# Altium schematic sheet artobot.SchDoc(artobot)
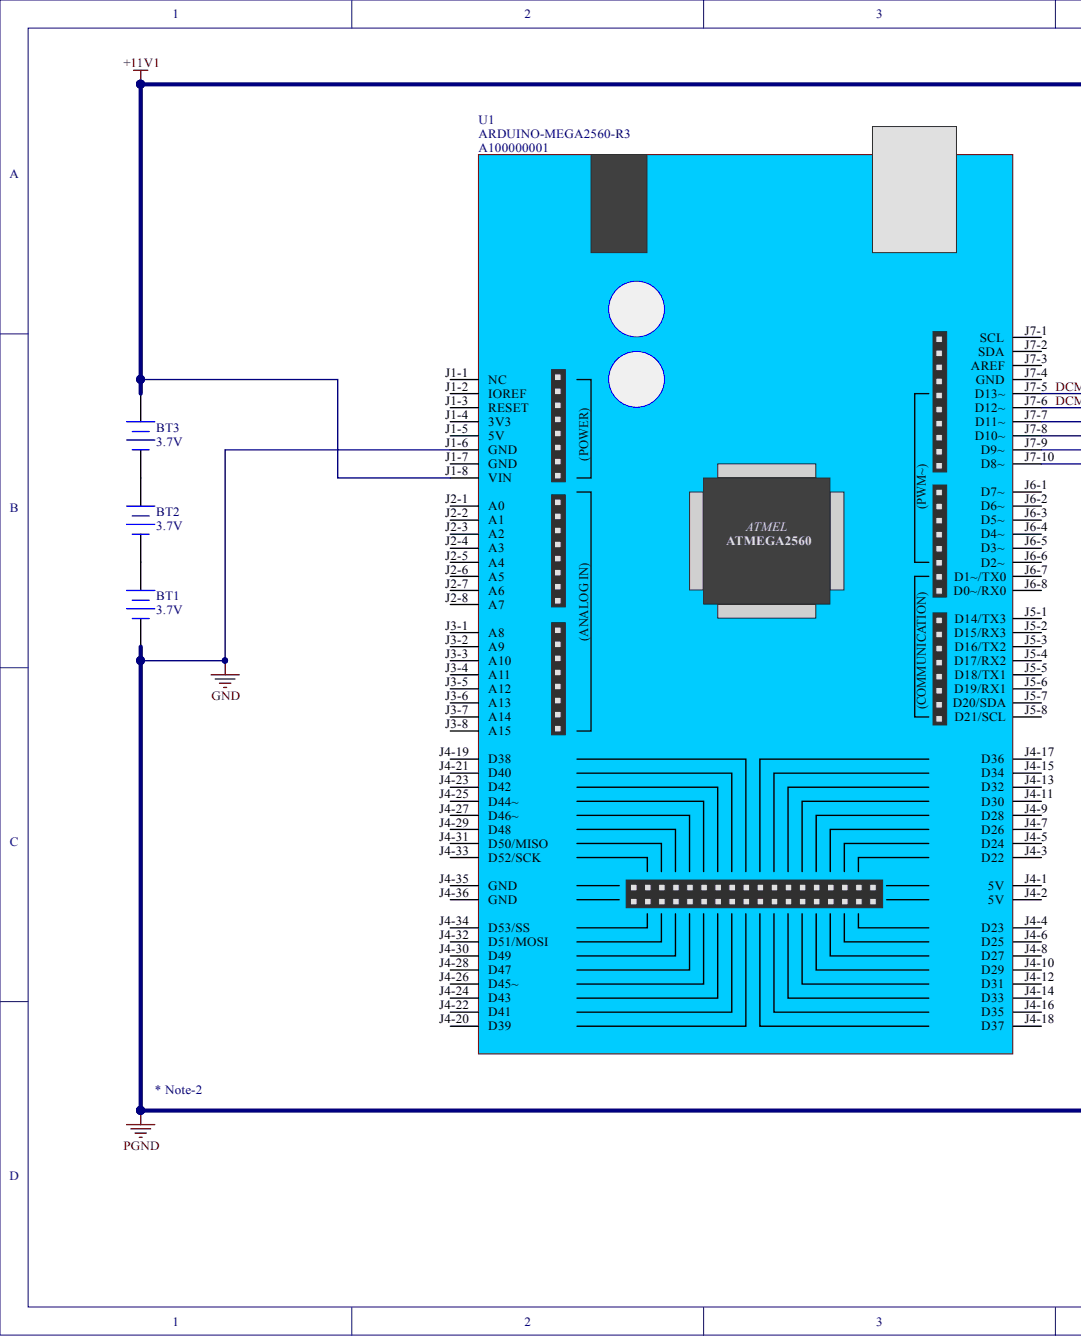
[diagram p0: artobot.SchDoc, left]
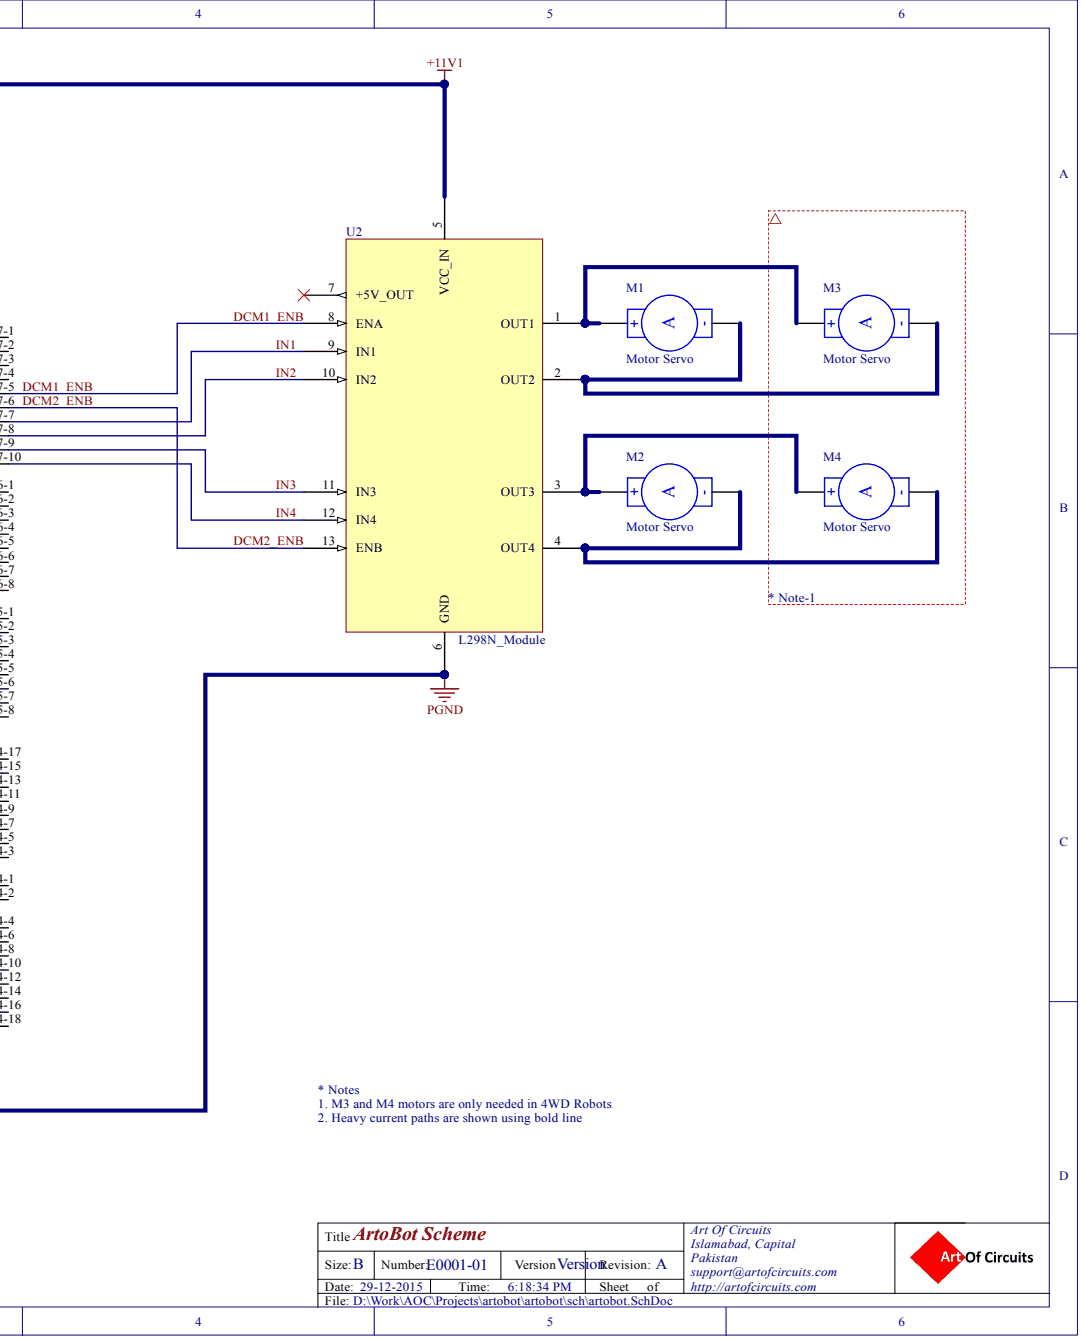
[diagram p1: artobot.SchDoc, right]

## Parts
BT1  3.7V  [BAT-2]
BT2  3.7V  [BAT-2]
BT3  3.7V  [BAT-2]
M1  Motor Servo  [RAD-0.4]
M2  Motor Servo  [RAD-0.4]
M3  Motor Servo  [RAD-0.4]
M4  Motor Servo  [RAD-0.4]
U1  ARDUINO-MEGA2560-R3  Supplier Part Number: A100000001  [ARDUINO-MEGA-2560-R3]
U2  L298N_Module

## Nets
+11V1: BT3.1 U1.J1-8(VIN) U2.5(VCC_IN)
GND: BT1.2 U1.J1-6(GND) U2.6(GND)
DCM1_ENB: U1.J7-5(D13~) U2.8(ENA)
DCM2_ENB: U1.J7-6(D12~) U2.13(ENB)
IN1: U1.J7-7(D11~) U2.9(IN1)
IN2: U1.J7-8(D10~) U2.10(IN2)
IN3: U1.J7-9(D9~) U2.11(IN3)
IN4: U1.J7-10(D8~) U2.12(IN4)
NetBT1_1: BT1.1 BT2.2
NetBT2_1: BT2.1 BT3.2
NetM1_1: M1.1 M3.1 U2.1(OUT1)
NetM1_2: M1.2 M3.2 U2.2(OUT2)
NetM2_1: M2.1 M4.1 U2.3(OUT3)
NetM2_2: M2.2 M4.2 U2.4(OUT4)

Not connected: U1 J1-1(NC) J1-2(IOREF) J1-3(RESET) J1-4(3V3) J1-5(5V) J1-7(GND) J2-1(A0) J2-2(A1) J2-3(A2) J2-4(A3) J2-5(A4) J2-6(A5) J2-7(A6) J2-8(A7) J3-1(A8) J3-2(A9) J3-3(A10) J3-4(A11) J3-5(A12) J3-6(A13) J3-7(A14) J3-8(A15) J4-1(5V) J4-2(5V) J4-3(D22) J4-4(D23) J4-5(D24) J4-6(D25) J4-7(D26) J4-8(D27) J4-9(D28) J4-10(D29) J4-11(D30) J4-12(D31) J4-13(D32) J4-14(D33) J4-15(D34) J4-16(D35) J4-17(D36) J4-18(D37) J4-19(D38) J4-20(D39) J4-21(D40) J4-22(D41) J4-23(D42) J4-24(D43) J4-25(D44~) J4-26(D45~) J4-27(D46~) J4-28(D47) J4-29(D48) J4-30(D49) J4-31(D50/MISO) J4-32(D51/MOSI) J4-33(D52/SCK) J4-34(D53/SS) J4-35(GND) J4-36(GND) J5-1(D14/TX3) J5-2(D15/RX3) J5-3(D16/TX2) J5-4(D17/RX2) J5-5(D18/TX1) J5-6(D19/RX1) J5-7(D20/SDA) J5-8(D21/SCL) J6-1(D7~) J6-2(D6~) J6-3(D5~) J6-4(D4~) J6-5(D3~) J6-6(D2~) J6-7(D1~/TX0) J6-8(D0~/RX0) J7-1(SCL) J7-2(SDA) J7-3(AREF) J7-4(GND); U2 7(+5V_OUT)

## Library data of the parts
BT1-BT3 = Battery: 18650 Li-ION Battery; Component Kind: Standard; Library Name: Miscellaneous Devices.IntLib
M1-M4 = Motor Servo: Servo Motor; Component Kind: Standard; LatestRevisionDate: 17-Jul-2002; LatestRevisionNote: Re-released for DXP Platform.; Library Name: Miscellaneous Devices.IntLib; PackageDescription: Radial Cap, Thru-Hole; 2 Leads; 0.4 in Pin Spacing; PackageReference: RAD-0.4; Published: 24-Mar-1999; Publisher: Altium Limited
U1 = ARDUINO-MEGA2560-R3: Arduino Mega 2560 R3 Embedded Microcontroller Board; Manufacturer: China; Component Kind: Standard; Component Link: http://artofcircuits.com/product/arduino-mega-2560-revision-3; Library Name: Arduino.SchLib; Supplier: Art of Circuits
U2 = L298N-MODULE: L298N Module; Component Kind: Standard; Library Name: L298N.SchLib
